# Altium schematic sheet 0_Main.SchDoc(0_Main)
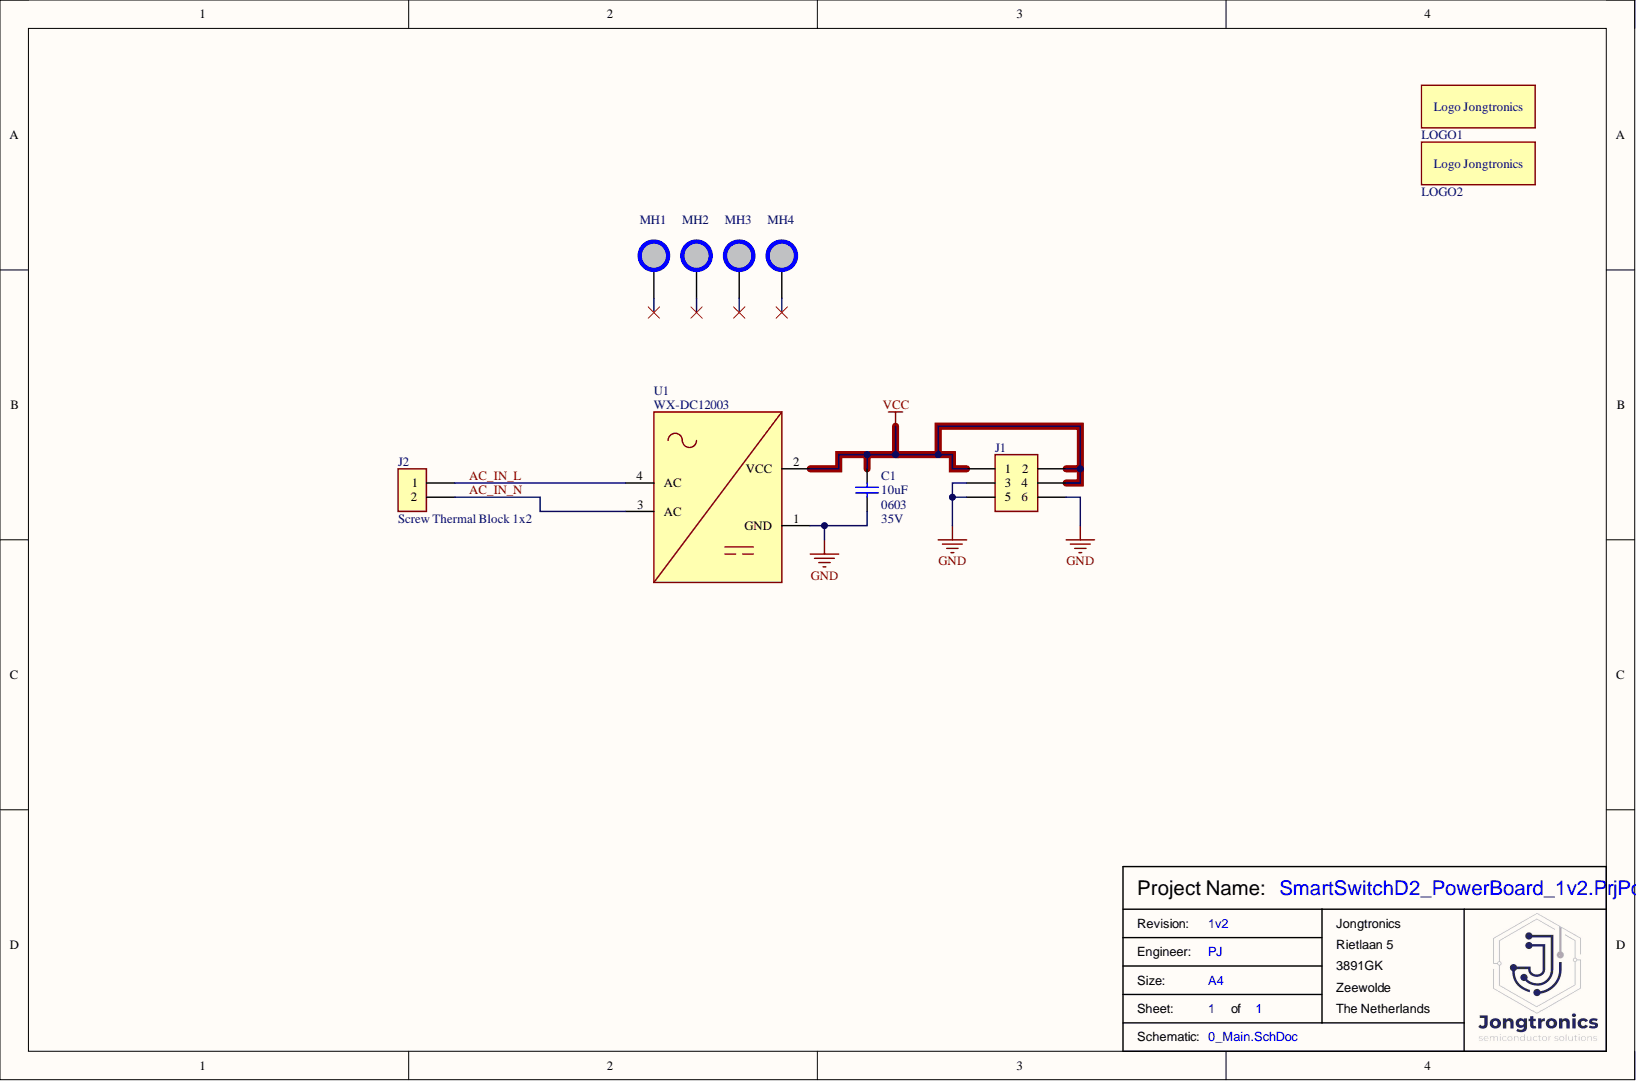
[diagram p0: 0_Main.SchDoc]

## Parts
C1  value 10uF  Rated Voltage: 35V  [0603]  (hidden comment: CAP-0603 10uF 35VDC 20% X5R)
J1  Header Male 2x3 - 3mm height - 0.05" (1.27mm)  [HEADER MALE 2x3 1.27MM]  (value not drawn)
J2  Thermal Block 9.5mm pitch 750V Screw terminal 1x2  [TH Block HB9500 1x2]  (value not drawn)
LOGO1  Logo Jongtronics  [LOGO_JONGTRONICS]  (no BOM)
LOGO2  Logo Jongtronics  [LOGO_JONGTRONICS]  (no BOM)
MH1  Spacer Threaded M3 x 4mm SMD  [SPACER THREADED M3 x 4mm]  (value not drawn)
MH2  Spacer Threaded M3 x 4mm SMD  [SPACER THREADED M3 x 4mm]  (value not drawn)
MH3  Spacer Threaded M3 x 4mm SMD  [SPACER THREADED M3 x 4mm]  (value not drawn)
MH4  Spacer Threaded M3 x 4mm SMD  [SPACER THREADED M3 x 4mm]  (value not drawn)
U1  AC-DC 5V 700mA Precision Buck Converter Step Down Transformator Module  [WX-DC12003]  (value not drawn)

## Nets
GND: C1.1 J1.3 J1.5 J1.6 U1.1(GND)
VCC: C1.2 J1.1 J1.2 J1.4 U1.2(VCC)
AC_IN_L: J2.1 U1.4(AC)
AC_IN_N: J2.2 U1.3(AC)

Not connected: MH1 MH; MH2 MH; MH3 MH; MH4 MH

## Library data of the parts
C1 = C 0603 10uF 35V: Manufacturer 1: Murata Electronics; Manufacturer Part Number 1: GRM188R6YA106MA73D; Case-Metric: 1608; Database Table Name: PJ_PASSIVE$; Default Designator: C?; Case-EIA: 0603; Component Kind: Standard; Datasheet: https://nl.mouser.com/datasheet/2/281/murata_03052018_GRM_Series_1-1310166.pdf; Description: CAP-0603 10uF 35VDC 20% X5R; Library Name: PJ_LIB.DbLib; Part Number: C 0603 10uF 35V; Supplier 1: Mouser; Supplier Part Number 1: 81-GRM188R6YA106MA3D; Tolerance: 20%
J1 = Header Male 2x3 0.05" (1.27mm): Header Male 2x3 - 4.68mm height - 0.05" (1.27mm); Manufacturer 1: Harwin; Manufacturer Part Number 1: M50-3600342; Database Table Name: PJ_MECH$; Default Designator: J?; Component Kind: Standard; Datasheet: https://nl.mouser.com/ProductDetail/Harwin/M50-3600342?qs=uLfVlVfCHH3O1msIjvLxbQ%3D%3D; Library Name: PJ_LIB.DbLib; Part Number: Header Male 2x3 0.05" (1.27mm); Supplier 1: Mouser; Supplier Part Number 1: 855-M50-3600342
J2 = Screw Thermal Block 1x2: Manufacturer 1: Cixi Kefa Elec; Manufacturer Part Number 1: HB9500-9.5-2P; Database Table Name: PJ_MECH$; Default Designator: J?; Component Kind: Standard; Datasheet: https://datasheet.lcsc.com/lcsc/2010111035_Cixi-Kefa-Elec-HB9500-9-5-2P_C707836.pdf; Description: Thermal Block 9.5mm pitch 750V Screw terminal 1x2; Library Name: PJ_LIB.DbLib; Part Number: Screw Thermal Block 1x2; Supplier 1: LCSC Electronics; Supplier Part Number 1: C707836
LOGO1 LOGO2 = Logo Jongtronics: Database Table Name: PJ_PASSIVE$; Default Designator: LOGO?; Description: Logo Jongtronics; Library Name: PJ_LIB.DbLib; Part Number: Logo Jongtronics
MH1-MH4 = Spacer Threaded M3 x 4mm: Manufacturer 1: Wurth Elektronik; Manufacturer Part Number 1: 78614040360; Database Table Name: PJ_MECH$; Default Designator: MH?; Component Kind: Standard; Datasheet: https://www.we-online.com/components/products/datasheet/78614040360.pdf; Description: Spacer Threaded M3 x 4mm SMD; Library Name: PJ_LIB.DbLib; Part Number: Spacer Threaded M3 x 4mm; Supplier 1: Mouser; Supplier Part Number 1: 710-78614040360
U1 = WX-DC12003: Database Table Name: PJ_ACTIVE$; Default Designator: U?; Component Kind: Standard; Description: AC-DC 5V 700mA Precision Buck Converter Step Down Transformator Module; Library Name: PJ_LIB.DbLib; Manufacturer Part Number 1: WX-DC12003; Part Number: WX-DC12003
